# Altium schematic sheet WiFi.SchDoc
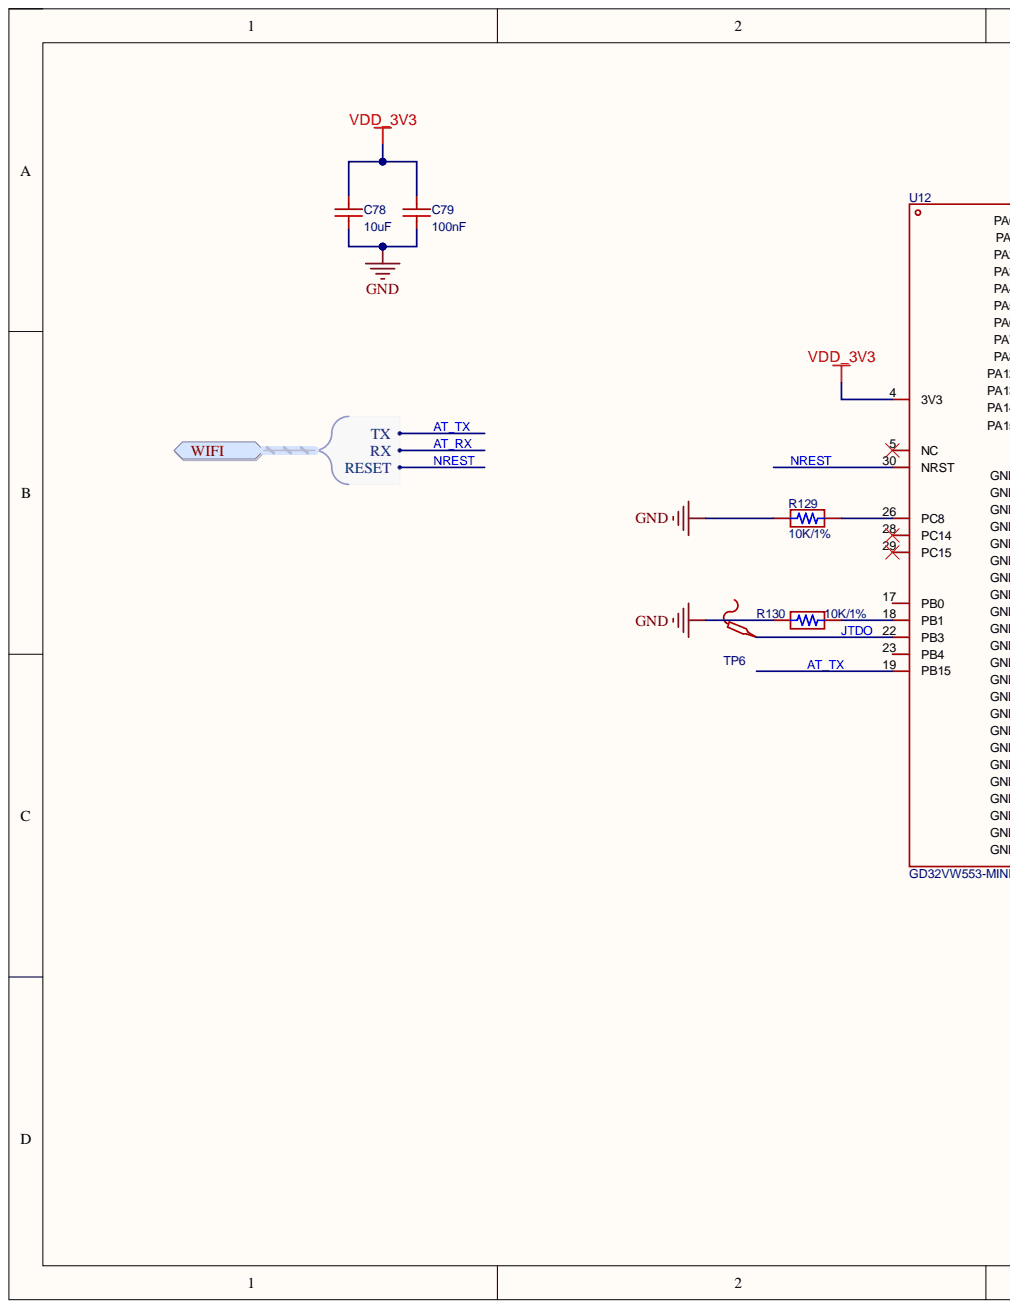
[diagram p0: WiFi.SchDoc, left]
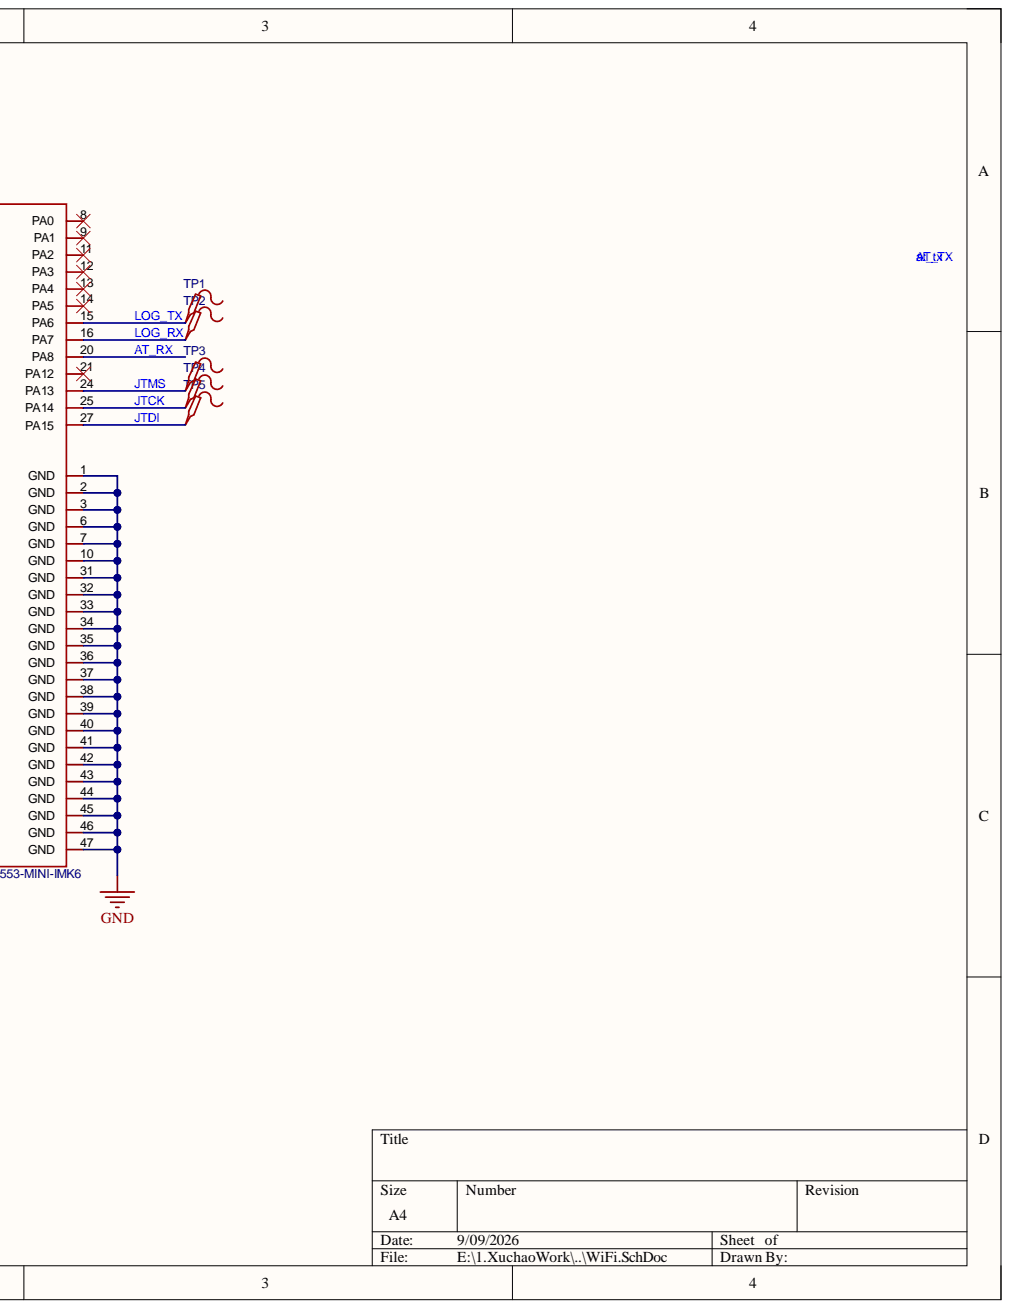
[diagram p1: WiFi.SchDoc, right]

## Parts
C78  10uF  [C0402]
C79  100nF  [C0402]
R129  10K/1%  [R0402]
R130  10K/1%  [R0402]
TP1  Test-Point  [Test-Point-0.5mm]  (value not drawn)
TP2  Test-Point  [Test-Point-0.5mm]  (value not drawn)
TP3  Test-Point  [Test-Point-0.5mm]  (value not drawn)
TP4  Test-Point  [Test-Point-0.5mm]  (value not drawn)
TP5  Test-Point  [Test-Point-0.5mm]  (value not drawn)
TP6  Test-Point  [Test-Point-0.5mm]  (value not drawn)
U12  GD32VW553-MINI-IMK6  [WIRELM-SMD_GD32VW553-MINI-IMK6]

## Ports
WIFI, WIFI.RESET, WIFI.RX, WIFI.TX

## Nets
GND: C78.1 C79.1 R129.2 R130.2 U12.1(GND) U12.2(GND) U12.3(GND) U12.6(GND) U12.7(GND) U12.10(GND) U12.31(GND) U12.32(GND) U12.33(GND) U12.34(GND) U12.35(GND) U12.36(GND) U12.37(GND) U12.38(GND) U12.39(GND) U12.40(GND) U12.41(GND) U12.42(GND) U12.43(GND) U12.44(GND) U12.45(GND) U12.46(GND) U12.47(GND)
VDD_3V3: C78.2 C79.2 U12.4(3V3)
AT_RX: U12.20(PA8) ; port WIFI
at_tx: U12.19(PB15) ; port WIFI
JTCK: TP4.1 U12.25(PA14)
JTDI: TP5.1 U12.27(PA15)
JTDO: TP6.1 U12.22(PB3)
JTMS: TP3.1 U12.24(PA13)
LOG_RX: TP2.1 U12.16(PA7)
LOG_TX: TP1.1 U12.15(PA6)
NREST: U12.30(NRST) ; port WIFI
NetR129_1: R129.1 U12.26(PC8)
NetR130_1: R130.1 U12.18(PB1)
NetU12_5: U12.5(NC)
NetU12_8: U12.8(PA0)
NetU12_9: U12.9(PA1)
NetU12_11: U12.11(PA2)
NetU12_12: U12.12(PA3)
NetU12_13: U12.13(PA4)
NetU12_14: U12.14(PA5)
NetU12_17: U12.17(PB0)
NetU12_21: U12.21(PA12)
NetU12_23: U12.23(PB4)
NetU12_28: U12.28(PC14)
NetU12_29: U12.29(PC15)

## Library data of the parts
C78 C79 = 0SMD_CAP: Symbol: CL10A106KP8NNNC; Component Kind: Standard; Library Name: CYKJ_LibPkg.IntLib
R129 R130 = Resisteors: RES_SMD; Component Kind: Standard; Library Name: CYKJ_library.SchLib
TP1-TP6 = Test-Point: Test Point 测试点; AddInBom: yes; Component Kind: Standard; ConvertToPcb: yes; Device: Test-Point; FootprintName: Test-Point-0.5mm; Symbol: Test-Point; Library Name: CYKJ_LibPkg.IntLib
U12 = MD_GD32VW553-MINI-IMK6: AddInBom: yes; ModelId: c37d5225be3a484895e0f6530189e47d聨0819f05c4eef4c71ace90d822a990e87; ModelTransform: 488.188,590.55,0,0,0,0,0,106.299,0; Component Kind: Standard; ConvertToPcb: yes; Device: GD32VW553-MINI-IMK6; FootprintName: WIRELM-SMD_GD32VW553-MINI-IMK6; LCSC Part Name: GD32VW553-MINI-IMK6; ManufacturerPart: GD32VW553-MINI-IMK6; ModelName: WIRELM-SMD_GD32VW553-MINI-IMK6; Supplier: LCSC; Supplier Footprint: 15 x 12.4 x 2.4; SupplierPart: C9900174619; Symbol: GD32VW553-MINI-IMK6; Library Name: CYKJ_LibPkg.IntLib
